# Altium schematic sheet Sheet_1_2022-03-02.schdoc
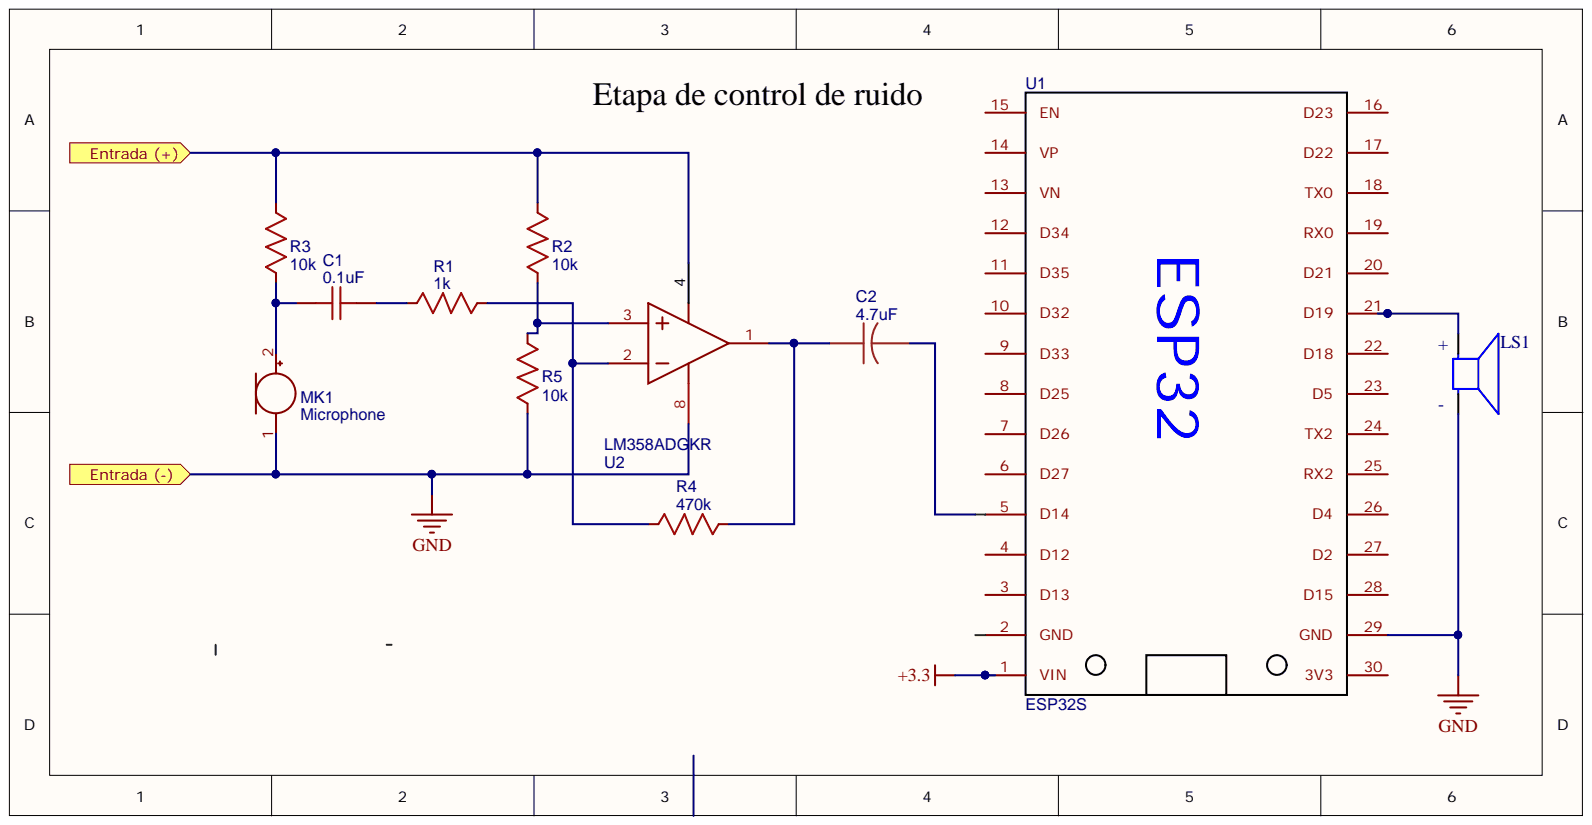
[diagram p0: Sheet_1_2022-03-02.schdoc]

## Parts
C1  0.1uF  [C0603]
C2  4.7uF  [CASE-A_3216]
LS1  AST-1732MR-R  [SPKR_AST-1732MR-R]  (value not drawn)
MK1  Microphone
R1  1k  [R0603]
R2  10k  [R0603]
R3  10k  [R0603]
R4  470k  [R0603]
R5  10k  [R0603]
U1  ESP32S  [ESP32]
U2  LM358ADGKR  [VSSOP-8_L3.0-W3.0-P0.65-LS5.0-BL]

## Ports
Entrada (+), Entrada (-)

## Nets
+3.3: U1.1(VIN)
GND: LS1.N MK1.1 R5.1 U1.29(GND) U2.8
NetC1_1: C1.1 MK1.2 R3.1
NetC1_2: C1.2 R1.1
NetC2_1: C2.1 R4.1 U2.1
NetC2_2: C2.2 U1.5(D14)
NetLS1_P: LS1.P U1.21(D19)
NetR1_2: R1.2 R4.2 U2.2
NetR2_1: R2.1 R5.2 U2.3
NetR2_2: R2.2 R3.2 U2.4
N00003:
N00004:
N00005:

Not connected: U1 2(GND) 3(D13) 4(D12) 6(D27) 7(D26) 8(D25) 9(D33) 10(D32) 11(D35) 12(D34) 13(VN) 14(VP) 15(EN) 16(D23) 17(D22) 18(TX0) 19(RX0) 20(D21) 22(D18) 23(D5) 24(TX2) 25(RX2) 26(D4) 27(D2) 28(D15) 30(3V3)

## Library data of the parts
C1 = 0.1uF: Contributor: LCEDA_Lib; nameAlias: Value(F); Component Kind: Standard; spicePre: C; spiceSymbolName: C_0603_US
C2 = 4.7uF: Contributor: LCEDA_Lib; nameAlias: Value(F); Component Kind: Standard; spicePre: C; spiceSymbolName: C_Tan_SMD_A_US
LS1 = AST-1732MR-R: æ‰¬å£°å™¨.2W 32æ¬§å§†90DBA 1700HZ; MF: PUI Audio; Availability: In Stock; Check_prices: https://www.snapeda.com/parts/AST-1732MR-R/PUI+Audio/view-part/?ref=eda; Component Kind: Standard; Library Name: AST-1732MR-R.IntLib; MP: AST-1732MR-R; Package: None; Price: None; Purchase-URL: https://pricing.snapeda.com/search/part/AST-1732MR-R/?ref=eda; SnapEDA_Link: https://www.snapeda.com/parts/AST-1732MR-R/PUI+Audio/view-part/?ref=snap
MK1 = Microphone: Contributor: KiCAD; Component Kind: Standard; license: https://www.gnu.org/licenses/lgpl-2.1.html; spicePre: M; spiceSymbolName: Microphone
R1 = 1k: Contributor: LCEDA_Lib; nameAlias: Value(Ω); Component Kind: Standard; spicePre: R; spiceSymbolName: R_0603_US
R2 R3 R5 = 10k: Contributor: LCEDA_Lib; nameAlias: Value(Ω); Component Kind: Standard; spicePre: R; spiceSymbolName: R_0603_US
R4 = 470k: Contributor: LCEDA_Lib; nameAlias: Value(Ω); Component Kind: Standard; spicePre: R; spiceSymbolName: R_0603_US
U1 = ESP32S: BOM_Manufacturer Part: New SchematicLib; Contributor: vincenzo.bini; Component Kind: Standard; Image: //myelectronicslab.com/wp-content/uploads/2017/10/esp32-pinout-node-mcu-board-.jpeg; spicePre: U; spiceSymbolName: ESP32S
U2 = LM358ADGKR: BOM_Manufacturer: Texas Instruments; subpart_no: 1; BOM_JLCPCB Part Class: Extended Part; BOM_Manufacturer Part: LM358ADGKR; BOM_Supplier: LCSC; BOM_Supplier Part: C484728; Component Kind: Standard; spicePre: U; spiceSymbolName: LM358ADGKR
